# Altium schematic sheet PCIe_USB30_Conn_Panel_Top.SchDoc(PCIe_USB30_Conn_Panel_Top)
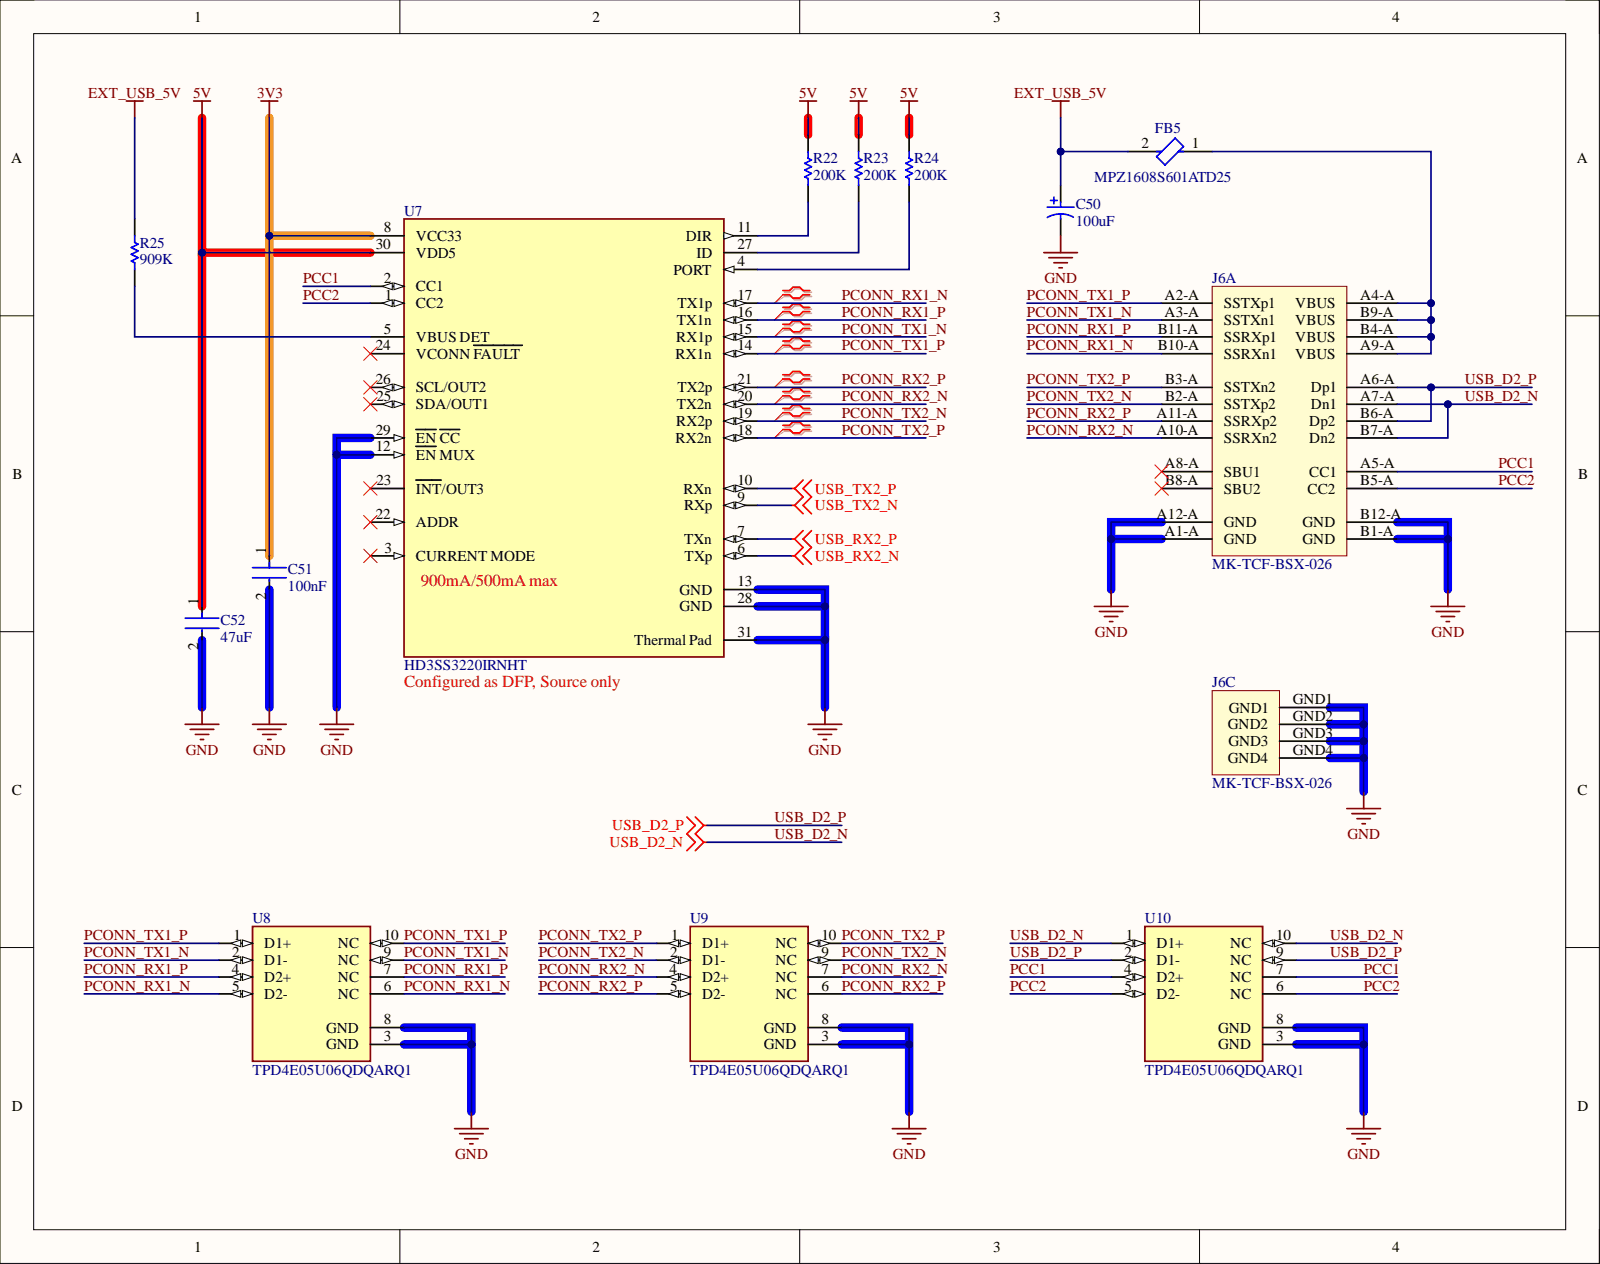
[diagram p0: PCIe_USB30_Conn_Panel_Top.SchDoc]

## Parts
C50  100uF  [PNSC-EEEFK1C101P_L]
C51  100nF  [FP-C0402-BB-MFG]
C52  47uF  [FP-C2012-125-0_2-IPC_B]
FB5  MPZ1608S601ATD25  [FP-MPZ1608-0_8-IPC_B]
J6  MK-TCF-BSX-026  [MK-TCF-BSX-026]  (parts A,C)
R22  200K  [RESC1005X40X25NL05T05]
R23  200K  [RESC1005X40X25NL05T05]
R24  200K  [RESC1005X40X25NL05T05]
R25  909K  [RESC1005X40X25NL05T10]
U7  HD3SS3220IRNHT  [FP-RNH0030A-MFG]
U8  TPD4E05U06QDQARQ1  [FP-DQA0010A-MFG]
U9  TPD4E05U06QDQARQ1  [FP-DQA0010A-MFG]
U10  TPD4E05U06QDQARQ1  [FP-DQA0010A-MFG]

## Nets
3V3: C51.1 U7.8(VCC33)
5V: C52.1 R22.2 R23.2 R24.2 U7.30(VDD5)
EXT_USB_5V: C50.1 FB5.2 R25.2
GND: C50.2 C51.2 C52.2 J6.A1-A(GND) J6.A12-A(GND) J6.B1-A(GND) J6.B12-A(GND) J6.GND1 J6.GND2 J6.GND3 J6.GND4 U7.12(EN_MUX) U7.13(GND) U7.28(GND) U7.29(EN_CC) U7.31(Thermal_Pad) U8.3(GND) U8.8(GND) U9.3(GND) U9.8(GND) U10.3(GND) U10.8(GND)
USB_RX2_N: U7.6(TXp)
USB_RX2_P: U7.7(TXn)
USB_TX2_N: U7.9(RXp)
USB_TX2_P: U7.10(RXn)
PCC1: J6.A5-A(CC1) U7.2(CC1) U10.4(D2+) U10.7(NC)
PCC2: J6.B5-A(CC2) U7.1(CC2) U10.5(D2-) U10.6(NC)
PCONN_RX1_N: J6.B10-A(SSRXn1) U7.17(TX1p) U8.5(D2-) U8.6(NC)
PCONN_RX1_P: J6.B11-A(SSRXp1) U7.16(TX1n) U8.4(D2+) U8.7(NC)
PCONN_RX2_N: J6.A10-A(SSRXn2) U7.20(TX2n) U9.4(D2+) U9.7(NC)
PCONN_RX2_P: J6.A11-A(SSRXp2) U7.21(TX2p) U9.5(D2-) U9.6(NC)
PCONN_TX1_N: J6.A3-A(SSTXn1) U7.15(RX1p) U8.2(D1-) U8.9(NC)
PCONN_TX1_P: J6.A2-A(SSTXp1) U7.14(RX1n) U8.1(D1+) U8.10(NC)
PCONN_TX2_N: J6.B2-A(SSTXp2) U7.19(RX2p) U9.2(D1-) U9.9(NC)
PCONN_TX2_P: J6.B3-A(SSTXn2) U7.18(RX2n) U9.1(D1+) U9.10(NC)
USB_D2_N: J6.A7-A(Dn1) J6.B7-A(Dn2) U10.1(D1+) U10.10(NC)
USB_D2_P: J6.A6-A(Dp1) J6.B6-A(Dp2) U10.2(D1-) U10.9(NC)
NetFB5_1: FB5.1 J6.A4-A(VBUS) J6.A9-A(VBUS) J6.B4-A(VBUS) J6.B9-A(VBUS)
NetR22_1: R22.1 U7.11(DIR)
NetR23_1: R23.1 U7.27(ID)
NetR24_1: R24.1 U7.4(PORT)
NetR25_1: R25.1 U7.5(VBUS_DET)

Not connected: J6 A8-A(SBU1) B8-A(SBU2); U7 3(CURRENT_MODE) 22(ADDR) 23(INT/OUT3) 24(VCONN_FAULT) 25(SDA/OUT1) 26(SCL/OUT2)

## Library data of the parts
C50 = CMP-2000-06203-1: Aluminum Electrolytic Capacitor, 100 uF, 20%, 16 V, -55 to 105 degC, 2-Pin SMD, RoHS, Tape and Reel; Manufacturer: Panasonic; Capacitance: 100µF; Impedance: 0.36O; Lifetime@Temperature: 2000Hrs@105°C; Rated Voltage: 16V; Ripple Current: 240mA; Type: Can; Case Size/Dimension: Ø6.3x5.8mm; Component Kind: Standard; Datasheet URL: http://datasheet.octopart.com/EEEFK1C101P-Panasonic-datasheet-13266987.pdf; Datasheet Version: 11/2012; Library Name: Altium Content Vault; Manufacturer URL: http://www.panasonic.com/; Mounting Technology: SurfaceMount; Operating Temp Range: -55to+105°C; Operating Temp-Max: 105°C; Package Description: 2-Pin Surface Mount Device, Body 6.6 x 6.6 mm; Package Reference: EEEFK1C101P; Series: FK
C51 = CMP-2008-02046-2: CAP CER 0.1UF 10V X5R 0402; Manufacturer: Kemet; Manufacturer Part Number: C0402C104K8PACTU; Capacitance: 0.10 μF; Material: Ceramic; Temperature Coefficient: 15%; Tolerance: 0.1; Value: 0.10 μF; Automotive: No; Category: Cap; Component Kind: Standard; ComponentLink2Description: Datasheet; ComponentLink2URL: https://content.kemet.com/datasheets/KEM_C1002_X7R_SMD.pdf; Device Class L1: Passive Components; Device Class L2: Capacitors; Device Class L3: Ceramic Capacitors; Digikey Description: CAP CER 0.1UF 10V X5R 0402; Height: 0.55mm; Ipc Land Pattern Name: CAPC10050X50; Lead Free: Yes; Library Name: Altium Content Vault; Mouser Description: Capacitor 100 nF 10 VDC 0402; Octopart: https://octopart.com/c0402c104k8pactu-kemet-140039; Package: C0402-BB; RoHS: TRUE; Temperature: -55°C to +125°C; Temperature Characteristic: X5R; Temperature Range High: +125°C; Temperature Range Low: -55°C; Voltage Rating: 10 V
C52 = CMP-2000-05451-2: Multilayer Ceramic Capacitors 47μF ±20% 10V X5R SMD 0805; Capacitance: 47uF; Case Code (Imperial): 0805; Case Code (Metric): 2012; Case/Package: 0805; Component Kind: Standard; Depth: 1.25mm; Dielectric: X5R; Dielectric Material: Ceramic; Height: 1.25mm; Lead Free: Lead Free; Length: 2mm; Library Name: Altium Content Vault; Max Operating Temperature: 85°C; Min Operating Temperature: -55°C; Mount: Surface Mount; Package Quantity: 1; Packaging: Tape and Reel; REACH SVHC: No SVHC; Resistor Type: Ceramic; RoHS Compliant: Yes; Series: C; Termination: SMD/SMT; Thickness: 0.057inch; Tolerance: 20%; Voltage: 10V; Voltage Rating: 10V; Voltage Rating (DC): 10V; Weight: 0.000194oz; Width: 0.049inch
FB5 = CMP-08257-000403-1: Power line Ferrite Bead 600Ω @ 100MHz 1A DCR 0.15Ω AEC-Q200 SMD 0603; DC Resistance (DCR): 150mR; Impedance: 600R; Number of Lines: 1; Tolerance: 25%; Case Code (Imperial): 0603; Case Code (Metric): 1608; Case/Package: 0603; Component Kind: Standard; Height: 0.037inch; Lead Free: Lead Free; Length: 0.063inch; Library Name: Altium Content Vault; Max Current Rating: 1A; Max DC Current: 1A; Max Operating Temperature: 125°C; Min Operating Temperature: -55°C; Mount: Surface Mount; Package Quantity: 4000; Packaging: Tape and Reel; Ratings: AEC-Q200; RoHS Compliant: Yes; Series: MPZ; Termination: SMD/SMT; Test Frequency: 100MHz; Weight: 7.1E-05oz; Width: 0.031inch
R22-R24 = CMP-1011-00768-4: Chip Resistor, 200 KOhm, +/- 1%, 100 mW, -55 to 155 degC, 0402 (1005 Metric), RoHS, Tape and Reel; Manufacturer: Basic Passives; PartNumber: ERJ-2RKF2003X; Case\/Package: 0402; Power Rating: 100 mW; Voltage Rating: 50 V; Case Code (Imperial): 0402; Case Code (Metric): 1005; Component Kind: Standard; ComponentLink1Description: Manufacturer URL; ComponentLink1URL: http://www.panasonic.com/; ComponentLink2Description: Datasheet; ComponentLink2URL: http://industrial.panasonic.com/cdbs/www-data/pdf/RDA0000/AOA0000C86.pdf; DatasheetVersion: 04/2015; Height: 0.35 mm; Length: 1 mm; Library Name: Altium Content Vault; Max Operating Temperature: 155 degC; Min Operating Temperature: -55 degC; Mount: Surface Mount; Mounting Technology: Surface Mount; Package Quantity: 10000; PackageDescription: 2-Pin Surface Mount Device, Body 1 x 0.5 mm; PackageReference: 0402; Packaging: Tape and Reel; Pins: 2; Radiation Hardening: No; REACH SVHC: No SVHC; Resistance: 200 KOhm; RoHSCompliant: true; Tolerance: 1%; Width: 0.5 mm
R25 = CMP-1011-00844-2: Manufacturer: Yageo / Phycomp; Part Number: RC0402FR-07909KL; Component Kind: Standard; Library Name: Altium Content Vault
U7 = CMP-04877-000019-1: USB TYPE-C DRP PORT CONTROLLER W; Data Rate: 10Gbps; Max Supply Voltage: 5.5V; Min Supply Voltage: 3V; Number of Ports: 1; USB Standard: USB 3.1; Component Kind: Standard; Lead Free: Lead Free; Library Name: Altium Content Vault; Max Operating Temperature: 70°C; Min Operating Temperature: 0°C; Mount: Surface Mount; Number of Pins: 30; Packaging: Tape and Reel; REACH SVHC: No SVHC; RoHS Compliant: Yes
U8-U10 = CMP-04985-000004-1: TVS DIODE 5.5V 14V 10USON; Manufacturer: Texas Instruments; Automotive Grade: Grade 1; Breakdown Voltage: 6.4V; Capacitance: 0.5pF; Direction: Unidirectional; Interface: USB,Ethernet,LVDS,Other; Number Of Channels: 4; Peak Pulse Current: 2.5A; Peak Pulse Power: 2.5W; Standoff Height: 0mm; Value: 0.5pF; Ambient Temperature Range High: +125°C; Ambient Temperature Range Low: -40°C; Automotive: Yes; Category: IC; Clamping Voltage: 14V; Component Kind: Standard; ComponentLink2Description: Datasheet; ComponentLink2URL: http://www.ti.com/lit/ds/symlink/tpd4e05u06-q1.pdf; Device Class L1: Circuit Protection; Device Class L2: ESD and Circuit Protection ICs; Device Class L3: unset; Digikey Description: TVS DIODE 5.5V 14V 10USON; Height: 0.55mm; Lead Free: Yes; Library Name: Altium Content Vault; Manufacturer Part Number: TPD4E05U06QDQARQ1; Mouser Description: Automotive 4-Channel ESD Protection Solution for SuperSpeed (up to 5 Gbps) Interface 10-USON -40 to 125; Octopart: https://octopart.com/tpd4e05u06qdqarq1-texas+instruments-48523459; Operating Supply Voltage: 5.5V; Package: DQA0010A; Reverse Standoff Voltage: 5.5V; RoHS: TRUE; Temperature: -40°C to +125°C; Temperature Range High: +125°C; Temperature Range Low: -40°C
J6 = MK-TCF-BSX-026: Component Kind: Standard; Library Name: MK-TCF-BSX-026.SchLib
